# Altium schematic sheet MSXII_DC-DC_Converter.SchDoc
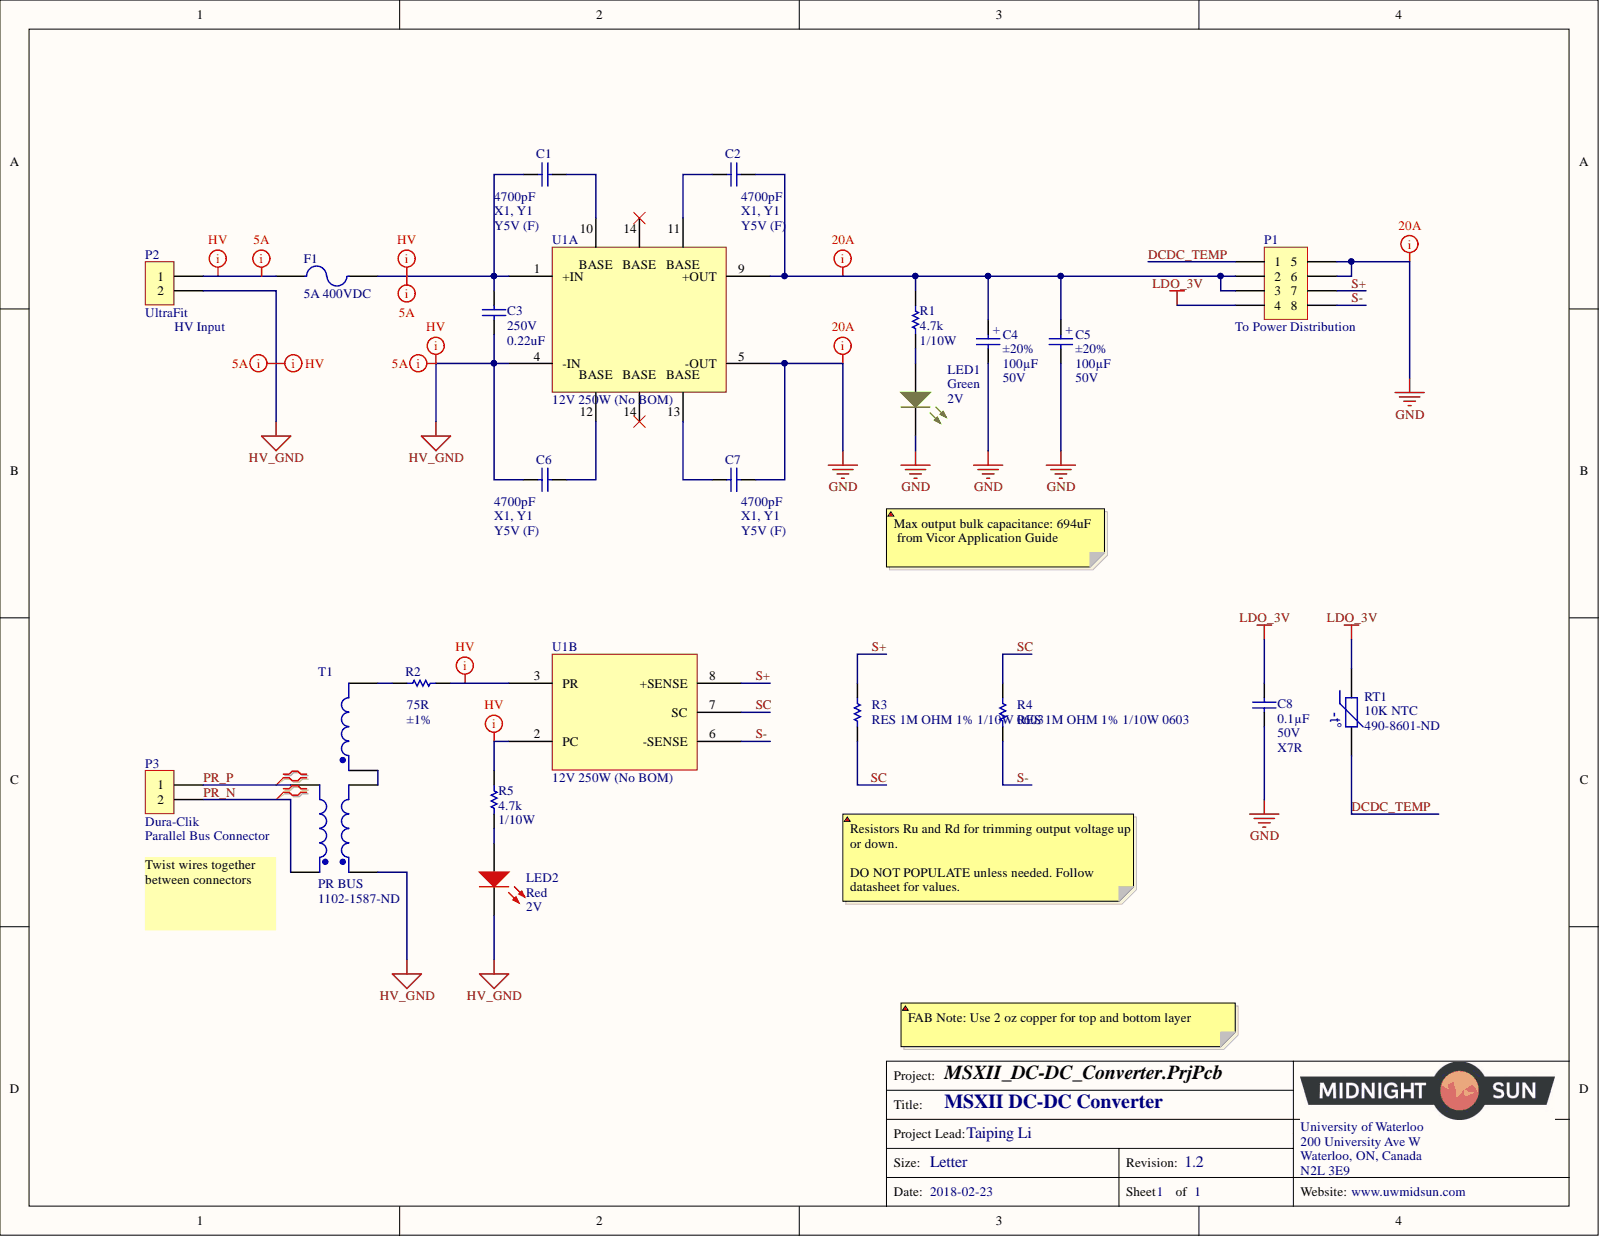
[diagram p0: MSXII_DC-DC_Converter.SchDoc]

## Parts
C1  value 4700pF  [CAP 4700PF 400VAC Y5V RADIAL]  (hidden comment: CAP CER 4700PF 400VAC Y5V RADIAL)
C2  value 4700pF  [CAP 4700PF 400VAC Y5V RADIAL]  (hidden comment: CAP CER 4700PF 400VAC Y5V RADIAL)
C3  value 0.22uF  Voltage Rating - DC: 250V  [CAP FILM 0.22UF 5% 250VDC RADIAL]  (hidden comment: CAP FILM 0.22UF 5% 250VDC RADIAL)
C4  Capacitance: 100µF  Tolerance: ±20%  Voltage Rating: 50V  [CAP ALUM 100UF 50V]  (hidden comment: CAP ALUM 100UF 20% 50V RADIAL)
C5  Capacitance: 100µF  Tolerance: ±20%  Voltage Rating: 50V  [CAP ALUM 100UF 50V]  (hidden comment: CAP ALUM 100UF 20% 50V RADIAL)
C6  value 4700pF  Ratings: X1, Y1  [CAP 4700PF 400VAC Y5V RADIAL]  (hidden comment: CAP CER 4700PF 400VAC Y5V RADIAL)
C7  value 4700pF  Ratings: X1, Y1  [CAP 4700PF 400VAC Y5V RADIAL]  (hidden comment: CAP CER 4700PF 400VAC Y5V RADIAL)
C8  Capacitance: 0.1µF  Temperature Coefficient: X7R  Voltage: 50V  [CAP, 0603]  (hidden comment: CAP CER 0.1UF 50V 10% X7R 0603)
F1  5A 400VDC  [FUSE 5A 400VDC RADIAL]
LED1  Color: Green  Voltage - Forward (Vf) (Typ): 2V  [LED_0603]  (hidden comment: LED GREEN CLEAR 2V 0603)
LED2  Color: Red  Voltage - Forward (Vf) (Typ): 2V  [LED_0603]  (hidden comment: LED RED CLEAR 2V 0603)
P1  CONN 8POS ULTRA-FIT 0.138"  [CONN, 8POS ULTRA-FIT]  (value not drawn)
P2  Series: UltraFit  [CONN, 2POS ULTRA-FIT]  (hidden comment: CONN 2POS ULTRA-FIT 0.138")
P3  Dura-Clik  [CONN, 2POS Dura-Click Vertical]
R1  Power (Watts): 1/10W  Resistance (Ohms): 4.7k  [RES, 0603]  (hidden comment: RES 4.7K OHM 1% 1/10W 0603)
R2  value 75R  [RES, 0603]  (hidden comment: RES 75R OHM 1% 1/10W 0603)
R3  RES 1M OHM 1% 1/10W 0603  [RES, 0603]  (no BOM)
R4  RES 1M OHM 1% 1/10W 0603  Power (Watts): 1/10W  Supplier Device Package: 0603  [RES, 0603]  (no BOM)
R5  Power (Watts): 1/10W  Resistance (Ohms): 4.7k  [RES, 0603]  (hidden comment: RES 4.7K OHM 1% 1/10W 0603)
RT1  10K NTC  Supplier Part Number 1: 490-8601-ND  [NTC THERMISTOR BEAD]
T1  PR BUS  Supplier Part Number 1: 1102-1587-ND  [XFMR PR BUS]  (no BOM)
U1  12V 250W (No BOM)  Voltage - Output 1: 12V  [DCDC VICOR 150V-12V 250W]  (no BOM)  (parts A,B)

## Nets
GND: C4.2 C5.2 C7.2 C8.2 LED1.2 P1.5 P1.6 U1.5(-OUT)
HV_GND: C3.1 C6.1 LED2.2 P2.2 T1.1 U1.4(-IN)
LDO_3V: C8.1 P1.4 RT1.1
DCDC_TEMP: P1.1 RT1.2
PR_N: P3.2 T1.5
PR_P: P3.1 T1.6
S+: P1.7 R3.1 U1.8(+SENSE)
S-: P1.8 R4.2 U1.6(-SENSE)
SC: R3.2 R4.1 U1.7(SC)
NetC1_1: C1.1 C3.2 F1.2 U1.1(+IN)
NetC1_2: C1.2 U1.10
NetC2_1: C2.1 U1.11
NetC2_2: C2.2 C4.1 C5.1 P1.2 P1.3 R1.1 U1.9(+OUT)
NetC6_2: C6.2 U1.12
NetC7_1: C7.1 U1.13
NetF1_1: F1.1 P2.1
NetLED1_1: LED1.1 R1.2
NetLED2_1: LED2.1 R5.2
NetR2_1: R2.1 T1.4
NetR2_2: R2.2 U1.3(PR)
NetR5_1: R5.1 U1.2(PC)
NetT1_2: T1.2 T1.3

Not connected: U1 14

## Library data of the parts
C1 C2 = CAP CER 4700PF 400VAC Y5V RADIAL: 4700pF ±20% 400VAC Ceramic Capacitor Y5V (F) Radial, Disc; Manufacturer: KEMET; Manufacturer Part Number: C951U472MVVDBA7317; Ratings: X1, Y1; Temperature Coefficient: Y5V (F); Applications: Safety; Category: Capacitors; Component Kind: Standard; ComponentLink1Description: https://search.kemet.com/component-edge/download/datasheet/C951U472MVVDBA7317.pdf; ComponentLink1URL: https://search.kemet.com/component-edge/download/datasheet/C951U472MVVDBA7317.pdf; Failure Rate: -; Features: -; Height - Seated (Max): -; Lead Spacing: 0.394" (10.00mm); Lead Style: Formed Leads - Kinked; Mounting Type: Through Hole; Operating Temperature: -40°C ~ 125°C; Package / Case: Radial, Disc; Packaging: Cut Tape (CT); Part Status: Active; Pricing 1: 1=1.12, 10=0.795, 100=0.5234, 500=0.38772 (CAD); RoHS: RoHS Compliant; Size / Dimension: 0.472" Dia (12.00mm); Stock 1: 9713; Supplier 1: Digi-Key; Supplier Part Number 1: 399-9517-1-ND; Thickness (Max): -; Tolerance: ±20%; Voltage - Rated: 400VAC; Library Name: Schematic Diagrams.SchLib
C3 = CAP FILM 0.22UF 5% 250VDC RADIAL: 0.22µF Film Capacitor 140V 250V Polyester, Polyethylene Terephthalate (PET), Metallized - Stacked Radial; Manufacturer: KEMET; Manufacturer Part Number: R82IC3220AA60J; Applications: Automotive; Category: Capacitors; Component Kind: Standard; ComponentLink1Description: https://search.kemet.com/component-edge/download/datasheet/R82IC3220AA60J.pdf; ComponentLink1URL: https://search.kemet.com/component-edge/download/datasheet/R82IC3220AA60J.pdf; Dielectric Material: Polyester, Polyethylene Terephthalate (PET), Metallized - Stacked; Features: -; Height - Seated (Max): 0.437" (11.10mm); Lead Spacing: 0.197" (5.00mm); Mounting Type: Through Hole; Operating Temperature: -55°C ~ 105°C; Package / Case: Radial; Packaging: Bulk; Part Status: Active; Pricing 1: 1=0.82, 10=0.581, 100=0.382, 500=0.28302, 1000=0.24056, 2500=0.22641, 5000=0.21226 (CAD); Ratings: AEC-Q200; RoHS: RoHS Compliant; Size / Dimension: 0.283" L x 0.236" W (7.20mm x 6.00mm); Stock 1: 6730; Supplier 1: Digi-Key; Supplier Part Number 1: 399-6046-ND; Termination: PC Pins; Tolerance: ±5%; Voltage Rating - AC: 140V; Library Name: Schematic Diagrams.SchLib
C4 C5 = CAP ALUM 100UF 20% 50V RADIAL: 100µF 50V Aluminum Capacitors Radial, Can 74 mOhm @ 100kHz 3000 Hrs @ 105°C; Manufacturer 1: KEMET; Manufacturer Part Number 1: ESY107M050AG3AA; Impedance: 74 mOhm; Polarization: Polar; Ripple Current - High Frequency: 730mA @ 100kHz; Ripple Current - Low Frequency: 511mA @ 120Hz; Applications: General Purpose; Category: Capacitors; Component Kind: Standard; ComponentLink1Description: http://capacitoredge.kemet.com/capedge2/DataSheet?pn=ESY107M050AG3AA; ComponentLink1URL: http://capacitoredge.kemet.com/capedge2/DataSheet?pn=ESY107M050AG3AA; Description 1: CAP ALUM 100UF 20% 50V RADIAL; ESR (Equivalent Series Resistance): 74 mOhm @ 100kHz; Height - Seated (Max): 0.492" (12.50mm); Lead Spacing: 0.138" (3.50mm); Lifetime @ Temp.: 3000 Hrs @ 105°C; Mounting Type: Through Hole; Operating Temperature: -40°C ~ 105°C; Package / Case: Radial, Can; Packaging: Bulk; Part Status: Active; Pricing 1: 1=0.5, 10=0.345, 100=0.2074, 500=0.15558, 1000=0.13138, 2500=0.12446, 5000=0.11755 (CAD); RoHS: RoHS Compliant; Size / Dimension: 0.315" Dia (8.00mm); Stock 1: 7068; Supplier 1: Digi-Key; Supplier Part Number 1: 399-6119-ND; Surface Mount Land Size: -; Library Name: Schematic Diagrams.SchLib
C6 C7 = CAP CER 4700PF 400VAC Y5V RADIAL: 4700pF ±20% 400VAC Ceramic Capacitor Y5V (F) Radial, Disc; Manufacturer: KEMET; Manufacturer Part Number: C951U472MVVDBA7317; Temperature Coefficient: Y5V (F); Applications: Safety; Category: Capacitors; Component Kind: Standard; ComponentLink1Description: https://search.kemet.com/component-edge/download/datasheet/C951U472MVVDBA7317.pdf; ComponentLink1URL: https://search.kemet.com/component-edge/download/datasheet/C951U472MVVDBA7317.pdf; Failure Rate: -; Features: -; Height - Seated (Max): -; Lead Spacing: 0.394" (10.00mm); Lead Style: Formed Leads - Kinked; Mounting Type: Through Hole; Operating Temperature: -40°C ~ 125°C; Package / Case: Radial, Disc; Packaging: Cut Tape (CT); Part Status: Active; Pricing 1: 1=1.12, 10=0.795, 100=0.5234, 500=0.38772 (CAD); RoHS: RoHS Compliant; Size / Dimension: 0.472" Dia (12.00mm); Stock 1: 9713; Supplier 1: Digi-Key; Supplier Part Number 1: 399-9517-1-ND; Thickness (Max): -; Tolerance: ±20%; Voltage - Rated: 400VAC; Library Name: Schematic Diagrams.SchLib
C8 = CAP CER 0.1UF 50V 10% X7R 0603: 0.10µF ±10% 50V Ceramic Capacitor X7R 0603 (1608 Metric); Manufacturer 1: AVX Corporation; Manufacturer Part Number 1: 06035C104KAT2A; Component Kind: Standard; ComponentLink1Description: http://datasheets.avx.com/X7RDielectric.pdf; ComponentLink1URL: http://datasheets.avx.com/X7RDielectric.pdf; Height - Seated (Max): -; Lead Spacing: -; Lead Style: -; Mounting Type: Surface Mount, MLCC; Operating Temperature: -55°C ~ 125°C; Package / Case: 0603 (1608 Metric); Packaging: Cut Tape (CT); Part Status: Active; Ratings: -; Size / Dimension: 0.063" L x 0.032" W (1.60mm x 0.81mm); Supplier 1: Digi-Key; Supplier Part Number 1: 478-5052-1-ND; Thickness (Max): 0.035" (0.90mm); Tolerance: ±10%; Library Name: Schematic Diagrams.SchLib
F1 = FUSE 5A 125VAC/400VDC RADIAL: FUSE BOARD MNT 5A 125VAC/400VDC; Manufacturer: Eaton; Manufacturer Part Number: BK/PCE-5-R; Approvals: CSA, UL; Breaking Capacity @ Rated Voltage: 10kA AC, 4.2kA DC; Fuse Type: Board Mount (Cartridge Style Excluded); Response Time: Fast; Category: Circuit Protection; Color: -; Component Kind: Standard; ComponentLink1Description: http://www.cooperindustries.com/content/dam/public/bussmann/Electronics/Resources/product-datasheets/Bus_Elx_DS_2034_PC_Tron_Series.pdf; ComponentLink1URL: http://www.cooperindustries.com/content/dam/public/bussmann/Electronics/Resources/product-datasheets/Bus_Elx_DS_2034_PC_Tron_Series.pdf; Mounting Type: Through Hole; Operating Temperature: -; Package / Case: Radial, Box; Packaging: Bulk; Part Status: Active; Pricing 1: 1=3.06, 5=2.91, 10=2.765, 50=2.2306, 100=1.8911, 250=1.8426, 500=1.55166, 1000=1.38196, 5000=1.21224 (CAD); RoHS: RoHS Compliant; Size / Dimension: 0.300" L x 0.095" W x 0.170" H (7.62mm x 2.41mm x 4.32mm); Stock 1: 0; Supplier 1: Digi-Key; Supplier Part Number 1: 283-2770-ND; Library Name: Schematic Diagrams.SchLib
LED1 = LED GREEN CLEAR 2V 0603: Green 572nm LED Indication - Discrete 2V 0603 (1608 Metric); Manufacturer: Wurth Electronics Inc.; Manufacturer Part Number: 150060VS75000; Current - Test: 20mA; Lens Color: Colorless; Lens Style/Size: Rectangle with Flat Top, 1.10mm x 0.70mm; Millicandela Rating: 40mcd; Viewing Angle: 140°; Wavelength - Dominant: 570nm; Category: Optoelectronics; Component Kind: Standard; ComponentLink1Description: http://katalog.we-online.de/led/datasheet/150060VS75000.pdf; ComponentLink1URL: http://katalog.we-online.de/led/datasheet/150060VS75000.pdf; Features: -; Height (Max): 0.80mm; Lens Transparency: Clear; Mounting Type: Surface Mount; Package / Case: 0603 (1608 Metric); Packaging: Cut Tape (CT); Part Status: Active; Pricing 1: 1=0.21, 100=0.1617, 500=0.13964 (CAD); RoHS: RoHS Compliant; Size / Dimension: 1.60mm L x 0.80mm W; Stock 1: 9408; Supplier 1: Digi-Key; Supplier Device Package: 0603; Supplier Part Number 1: 732-4980-1-ND; Wavelength - Peak: 572nm; Library Name: Schematic Diagrams.SchLib
LED2 = LED RED CLEAR 2V 0603: Red 631nm LED Indication - Discrete 2V 0603 (1608 Metric); Manufacturer: Wurth Electronics Inc.; Manufacturer Part Number: 150060RS75000; Current - Test: 20mA; Lens Color: Colorless; Lens Style/Size: Rectangle with Flat Top, 1.10mm x 0.70mm; Millicandela Rating: 250mcd; Viewing Angle: 140°; Wavelength - Dominant: 625nm; Wavelength - Peak: 630nm; Category: Optoelectronics; Component Kind: Standard; ComponentLink1Description: http://katalog.we-online.de/led/datasheet/150060RS75000.pdf; ComponentLink1URL: http://katalog.we-online.de/led/datasheet/150060RS75000.pdf; Features: -; Height (Max): 0.80mm; Lens Transparency: Clear; Mounting Type: Surface Mount; Package / Case: 0603 (1608 Metric); Packaging: Cut Tape (CT); Part Status: Active; Pricing 1: 1=0.21, 100=0.1617, 500=0.13964 (CAD); RoHS: RoHS Compliant; Size / Dimension: 1.60mm L x 0.80mm W; Stock 1: 35521; Supplier 1: Digi-Key; Supplier Device Package: 0603; Supplier Part Number 1: 732-4978-1-ND; Library Name: Schematic Diagrams.SchLib
P1 = CONN 8POS ULTRA-FIT 0.138": 8 Positions Header Connector 0.138" (3.50mm) Through Hole Tin; Manufacturer: Molex, LLC; Manufacturer Part Number: 1722991108; Contact Length - Post: 0.126" (3.20mm); Mated Stacking Heights: 26.99mm; Material Flammability Rating: UL94 V-0; Number of Positions: 8; Number of Positions Loaded: All; Row Spacing - Mating: 0.181" (4.60mm); Shrouding: Shrouded - 4 Wall; Style: Board to Cable/Wire; Voltage Rating: 400V; Applications: Automotive, General Purpose, Industrial, Lighting, Medical, Military, Telecommunications; Category: Connectors, Interconnects; Component Kind: Standard; ComponentLink1Description: http://www.molex.com/pdm_docs/sd/1722991108_sd.pdf; ComponentLink1URL: http://www.molex.com/pdm_docs/sd/1722991108_sd.pdf; Connector Type: Header; Contact Finish - Mating: Tin; Contact Finish - Post: Tin; Contact Finish Thickness - Mating: 60µin (1.52µm); Contact Finish Thickness - Post: 60µin (1.52µm); Contact Length - Mating: -; Contact Material: Copper Alloy; Contact Shape: Square; Contact Type: Male Pin; Current Rating: -; Fastening Type: Latch Holder; Features: Board Guide, Solder Retention; Ingress Protection: -; Insulation Color: Black; Insulation Height: 0.465" (11.80mm); Insulation Material: Liquid Crystal Polymer (LCP); Mounting Type: Through Hole; Number of Rows: 2; Operating Temperature: -40°C ~ 105°C; Overall Contact Length: -; Packaging: Tray; Part Status: Active; Pitch - Mating: 0.138" (3.50mm); Pricing 1: 1=2.12, 10=1.923, 50=1.7262, 100=1.6478, 250=1.49084, 500=1.37314, 1000=1.17697, 2500=1.0985, 5000=1.04358 (CAD); RoHS: RoHS Compliant; Stock 1: 60; Supplier 1: Digi-Key; Supplier Part Number 1: WM11779-ND; Termination: Solder; Library Name: Schematic Diagrams.SchLib
P2 = CONN 2POS ULTRA-FIT 0.138": 2 Positions Header, Shrouded Connector 0.138" (3.50mm) Through Hole Gold; Manufacturer 1: Molex, LLC; Manufacturer Part Number 1: 1722861302; Contact Length - Post: 0.126" (3.20mm); Mated Stacking Heights: 26.99mm; Material Flammability Rating: UL94 V-0; Number of Positions: 2; Number of Positions Loaded: All; Shrouding: Shrouded - 4 Wall; Style: Board to Board or Cable; Voltage: 400V; Component Kind: Standard; ComponentLink1Description: http://www.molex.com/pdm_docs/sd/1722861302_sd.pdf; ComponentLink1URL: http://www.molex.com/pdm_docs/sd/1722861302_sd.pdf; Connector Type: Header; Contact Finish - Mating: Gold; Contact Finish - Post: Tin; Contact Finish Thickness - Mating: 30µin (0.76µm); Contact Finish Thickness - Post: 60µin (1.52µm); Contact Length - Mating: -; Contact Material: Copper Alloy; Contact Shape: Square; Contact Type: Male Pin; Current Rating: -; Fastening Type: Latch Holder; Ingress Protection: -; Insulation Color: Black; Insulation Height: 0.465" (11.80mm); Insulation Material: Liquid Crystal Polymer (LCP); Mounting Type: Through Hole; Number of Rows: 1; Operating Temperature: -40°C ~ 120°C; Overall Contact Length: -; Packaging: Tray; Part Status: Active; Pitch - Mating: 0.138" (3.50mm); Row Spacing - Mating: -; Supplier 1: Digi-Key; Supplier Part Number 1: WM11673-ND; Termination: Kinked Pin, Solder; Library Name: Schematic Diagrams.SchLib
P3 = CONN 2POS DURA-CLIK 0.079" VERT: 2 Positions Header, Shrouded Connector 0.079" (2.00mm) Surface Mount, Vertical Tin; Manufacturer: Molex, LLC; Manufacturer Part Number: 5600200220; Mated Stacking Heights: 9.3mm; Number of Positions: 2; Number of Positions Loaded: All; Shrouding: Shrouded - 4 Wall; Style: Board to Cable/Wire; Applications: Automotive, Industrial; Category: Connectors, Interconnects; Component Kind: Standard; ComponentLink1Description: http://www.molex.com/pdm_docs/sd/5600200220_sd.pdf; ComponentLink1URL: http://www.molex.com/pdm_docs/sd/5600200220_sd.pdf; Connector Type: Header; Contact Finish - Mating: Tin; Contact Finish - Post: Tin; Contact Finish Thickness - Mating: -; Contact Finish Thickness - Post: -; Contact Length - Mating: -; Contact Length - Post: -; Contact Material: Copper Alloy; Contact Shape: Square; Contact Type: Male Pin; Current Rating: -; Fastening Type: Latch Lock; Features: Solder Retention; Ingress Protection: -; Insulation Color: Natural; Insulation Height: 0.315" (8.00mm); Insulation Material: Polyamide (PA), Nylon; Material Flammability Rating: -; Mounting Type: Surface Mount; Number of Rows: 1; Operating Temperature: -; Overall Contact Length: -; Packaging: Cut Tape (CT); Part Status: Active; Pitch - Mating: 0.079" (2.00mm); Pricing 1: 1=1.16, 10=1.012, 25=0.952, 50=0.9122, 100=0.8727, 250=0.79336 (CAD); RoHS: RoHS Compliant; Row Spacing - Mating: -; Stock 1: 11912; Supplier 1: Digi-Key; Supplier Part Number 1: WM10862CT-ND; Termination: Solder; Voltage Rating: -; Library Name: Schematic Diagrams.SchLib
R1 R5 = RES 4.7K OHM 1% 1/10W 0603: 4.7k Ohm ±1% 0.1W, 1/10W Chip Resistor 0603 (1608 Metric) Moisture Resistant Thick Film; Manufacturer 1: Yageo; Manufacturer Part Number 1: RC0603FR-074K7L; Description 1: RES SMD 4.7K OHM 1% 1/10W 0603; Temperature Coefficient: ±100ppm/°C; Category: Resistors; Component Kind: Standard; ComponentLink1Description: http://www.yageo.com/documents/recent/PYu-RC_Group_51_RoHS_L_7.pdf; ComponentLink1URL: http://www.yageo.com/documents/recent/PYu-RC_Group_51_RoHS_L_7.pdf; Composition: Thick Film; Failure Rate: -; Features: Moisture Resistant; Height - Seated (Max): 0.022" (0.55mm); Operating Temperature: -55°C ~ 155°C; Package / Case: 0603 (1608 Metric); Packaging: Cut Tape (CT); Part Status: Active; Pricing 1: 1=0.16, 10=0.022, 100=0.0089, 1000=0.00402, 2500=0.00349 (CAD); RoHS: RoHS Compliant; Size / Dimension: 0.063" L x 0.031" W (1.60mm x 0.80mm); Stock 1: 3487566; Supplier 1: Digi-Key; Supplier Device Package: 0603; Supplier Part Number 1: 311-4.70KHRCT-ND; Tolerance: ±1%; Library Name: Schematic Diagrams.SchLib
R2 = RES 75R OHM 1% 1/10W 0603: 75 Ohms ±1% 0.1W, 1/10W Chip Resistor 0603 (1608 Metric) Automotive AEC-Q200, Moisture Resistant Thick Film; Manufacturer: Yageo; Manufacturer Part Number: AC0603FR-0775RL; Temperature Coefficient: ±100ppm/°C; Category: Resistors; Component Kind: Standard; ComponentLink1Description: http://www.yageo.com/NewPortal/yageodocoutput?fileName=/pdf/R-Chip/PYu-AC_51_RoHS_L_6.pdf; ComponentLink1URL: http://www.yageo.com/NewPortal/yageodocoutput?fileName=/pdf/R-Chip/PYu-AC_51_RoHS_L_6.pdf; Composition: Thick Film; Failure Rate: -; Features: Automotive AEC-Q200, Moisture Resistant; Height - Seated (Max): 0.022" (0.55mm); Operating Temperature: -55°C ~ 155°C; Package / Case: 0603 (1608 Metric); Packaging: Cut Tape (CT); Part Status: Active; Power (Watts): 0.1W, 1/10W; Pricing 1: 1=0.15, 10=0.036, 100=0.0145, 1000=0.00652, 2500=0.00566 (CAD); RoHS: RoHS Compliant; Size / Dimension: 0.063" L x 0.032" W (1.60mm x 0.80mm); Stock 1: 20391; Supplier 1: Digi-Key; Supplier Device Package: 0603; Supplier Part Number 1: YAG3630CT-ND; Tolerance: ±1%; Library Name: Schematic Diagrams.SchLib
R3 R4 = RES 1M OHM 1% 1/10W 0603: 1M Ohm ±1% 0.1W, 1/10W Chip Resistor 0603 (1608 Metric) Moisture Resistant Thick Film; Manufacturer 1: Yageo; Manufacturer Part Number 1: RC0603FR-071ML; Description 1: RES SMD 1M OHM 1% 1/10W 0603; Temperature Coefficient: ±100ppm/°C; Category: Resistors; ComponentLink1Description: http://www.yageo.com/documents/recent/PYu-RC_Group_51_RoHS_L_7.pdf; ComponentLink1URL: http://www.yageo.com/documents/recent/PYu-RC_Group_51_RoHS_L_7.pdf; Composition: Thick Film; Failure Rate: -; Features: Moisture Resistant; Height - Seated (Max): 0.022" (0.55mm); Operating Temperature: -55°C ~ 155°C; Package / Case: 0603 (1608 Metric); Packaging: Cut Tape (CT); Part Status: Active; Pricing 1: 1=0.16, 10=0.022, 100=0.0089, 1000=0.00402, 2500=0.00349 (CAD); Resistance (Ohms): 1M; RoHS: RoHS Compliant; Size / Dimension: 0.063" L x 0.031" W (1.60mm x 0.80mm); Stock 1: 2336711; Supplier 1: Digi-Key; Supplier Part Number 1: 311-1.00MHRCT-ND; Tolerance: ±1%; Library Name: Schematic Diagrams.SchLib
  R3: Power (Watts): 1/10W; Supplier Device Package: 0603
RT1 = NTC THERMISTOR 10K 1% BEAD: NTC Thermistor 10k Bead; Manufacturer: Murata Electronics North America; Manufacturer Part Number: NXRT15XH103FA1B030; B25/50: 3380K; B25/85: 3434K; B25/100: 3455K; B Value Tolerance: ±1%; B0/50: -; B25/75: -; Category: Sensors, Transducers; Component Kind: Standard; ComponentLink1Description: https://www.murata.com/~/media/webrenewal/support/library/catalog/products/thermistor/ntc/r44e.ashx; ComponentLink1URL: https://www.murata.com/~/media/webrenewal/support/library/catalog/products/thermistor/ntc/r44e.ashx; Length - Lead Wire: -; Mounting Type: Through Hole; Operating Temperature: -40°C ~ 125°C; Package / Case: Bead; Packaging: Bulk; Part Status: Active; Pricing 1: 1=0.96, 5=0.91, 10=0.792, 25=0.696, 50=0.5784, 100=0.4925, 500=0.42834, 1000=0.3748, 5000=0.33197 (CAD); Resistance in Ohms @ 25°C: 10k; Resistance Tolerance: ±1%; RoHS: RoHS Compliant; Stock 1: 25017; Supplier 1: Digi-Key; Library Name: Schematic Diagrams.SchLib
T1 = XFMR PR BUS: Transformer for PR bus on Vicor DC-DC; Manufacturer: Vicor Corporation; Manufacturer Part Number: 29768; For Use With/Related Products: VICOR Maxi, Mini, Micro-Sized Modules; Accessory Type: Transformer; Category: Power Supplies - Board Mount; ComponentLink1Description: http://asp.vicorpower.com/CADPDF/899N5I.PDF; ComponentLink1URL: http://asp.vicorpower.com/CADPDF/899N5I.PDF; Part Status: Active; Pricing 1: 1=15.2, 10=13.82, 25=12.7844, 100=11.7476, 250=10.711, 500=10.01996, 1000=9.19073 (CAD); RoHS: RoHS Compliant; Stock 1: 2198; Supplier 1: Digi-Key; Library Name: Schematic Diagrams.SchLib
U1 = DCDC VICOR 150V-12V 250W: Isolated Module DC DC Converter 1 Output 12V 20.83A 100V - 200V Input; Manufacturer: Vicor Corporation; Manufacturer Part Number: V150B12C250BL; Efficiency: 88.1%; Number of Outputs: 1; Voltage - Isolation: 3kV (3000V); Applications: ITE (Commercial); Category: Power Supplies - Board Mount; ComponentLink1Description: http://cdn.vicorpower.com/documents/datasheets/ds_150vin-mini-family.pdf; ComponentLink1URL: http://cdn.vicorpower.com/documents/datasheets/ds_150vin-mini-family.pdf; Current - Output (Max): 20.83A; Features: OVP, UVLO; Mounting Type: Through Hole; Operating Temperature: -20°C ~ 100°C; Package / Case: 9-DIP Module; Packaging: Bulk; Part Status: Active; Pricing 1: 1=252.65 (CAD); RoHS: RoHS non-compliant; Size / Dimension: 2.28" L x 2.20" W x 0.50" H (57.9mm x 55.9mm x 12.7mm); Stock 1: 0; Supplier 1: Digi-Key; Supplier Part Number 1: 1102-1234-ND; Type: Isolated Module; Voltage - Input (Max): 200V; Voltage - Input (Min): 100V; Voltage - Output 2: -; Voltage - Output 3: -; Library Name: Schematic Diagrams.SchLib
